# Altium schematic sheet Buck_v1.0_Schematic.SchDoc(Buck_v1.0_Schematic)
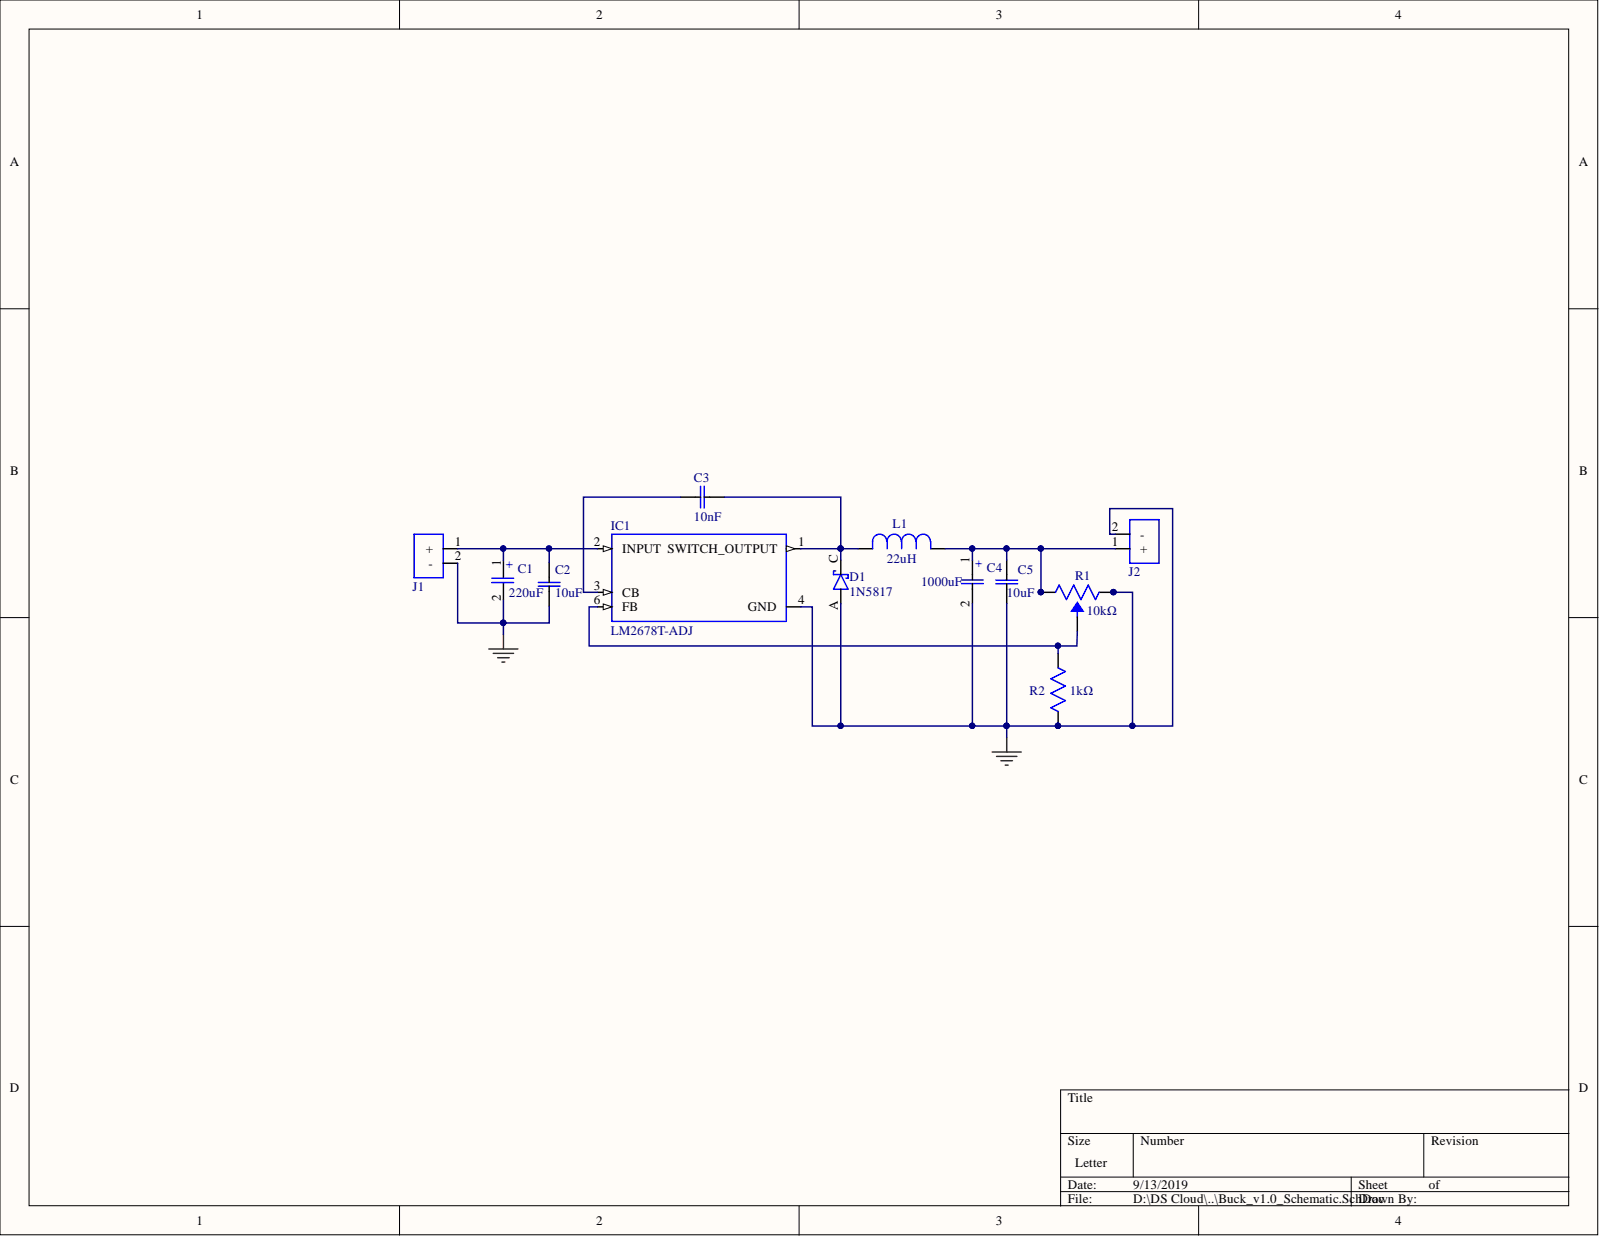
[diagram p0: Buck_v1.0_Schematic.SchDoc]

## Parts
C1  220uF  [Capacitor_220uF_50v]
C2  10uF  [Capacitor_10uF_50v]
C3  10nF  [Capacitor_10nF_50v]
C4  1000uF  [Capacitor_1000uF_50v]
C5  10uF  [Capacitor_10uF_50v]
D1  1N5817  [Diode_Schottky_1A]
IC1  LM2678T-ADJ  [IC_LM2678T-ADJ]
J1  Terminal-2  [Connector_Screw2]  (value not drawn)
J2  Terminal-2  [Connector_Screw2]  (value not drawn)
L1  22uH  [Inductor_22uH]
R1  10kΩ  [POT 10K]
R2  1kΩ  [Resistor_1kohm]

## Nets
GND: C1.2 C2.2 C4.2 C5.1 D1.A IC1.4(GND) J1.2 J2.2 R1.3 R2.1
NetC1_1: C1.1 C2.1 IC1.2(INPUT) J1.1
NetC3_1: C3.1 IC1.3(CB)
NetC3_2: C3.2 D1.C IC1.1(SWITCH_OUTPUT) L1.2
NetC4_1: C4.1 C5.2 J2.1 L1.1 R1.1
NetIC1_6: IC1.6(FB) R1.2 R2.2

## Library data of the parts
C1 = UVZ1H221MPD: CAP ALUM 220UF 20% 50V RADIAL; Manufacturer: Nichicon; Ripple Current: 300mA; Life (Hours): 1000Hour; Leakage Current: 330uA; Lead Diameter: 0.6mm; Dissipation Factor: 0.12%; Dielectric Material: Aluminium; Voltage Rating (DC): 50V; Voltage Rating: 50V; Voltage: 50V; Tolerance: 20%; Termination: Radial; Supplier Part Number 1: 493-1342-ND; Supplier 1: Digi-Key; Stock 1: 28523; RoHS: Yes; Radiation Hardening: No; Pricing 1: 1 = 0.50000, 10 = 0.34700, 100 = 0.20830, 500 = 0.15624, 1000 = 0.13194, 2500 = 0.12499, 5000 = 0.11805 (USD); Packaging: Bulk; Package Quantity: 200; Number of Pins: 2; Mount: Through Hole,PCB; Max Operating Temperature: 105°C; Manufacturer Part Number: UVZ1H221MPD; Library Name: Buck_v1.0_SchematicLib.SchLib; Length: 12.5mm; Lead Pitch: 5mm; Lead Free: Lead Free; Height - Seated (Max): 0.394inch; Height: 12.5mm; Features: General Purpose; Diameter: 10mm; Contact Plating: Tin,Matte; ComponentLink5URL: https://datasheet.ciiva.com/15199/getdatasheetpartid-434790-15199260.pdf?src-supplier=Verical; ComponentLink5Description: https://datasheet.ciiva.com/15199/getdatasheetpartid-434790-15199260.pdf?src-supplier=Verical; ComponentLink4URL: https://datasheet.ciiva.com/14609/70187813-14609113.pdf?src-supplier=Allied+Electronics; ComponentLink4Description: https://datasheet.ciiva.com/14609/70187813-14609113.pdf?src-supplier=Allied+Electronics; ComponentLink3URL: https://datasheet.ciiva.com/11685/e-vz-11685880.pdf?src-supplier=Avnet; ComponentLink3Description: https://datasheet.ciiva.com/11685/e-vz-11685880.pdf?src-supplier=Avnet; ComponentLink2URL: https://datasheet.ciiva.com/5330/e-vz-6403-5330789.pdf?src-supplier=Mouser; ComponentLink2Description: https://datasheet.ciiva.com/5330/e-vz-6403-5330789.pdf?src-supplier=Mouser; ComponentLink1URL: https://datasheet.ciiva.com/97/e-vz-97424.pdf?src-supplier=Digi-Key; ComponentLink1Description: https://datasheet.ciiva.com/97/e-vz-97424.pdf?src-supplier=Digi-Key; Component Kind: Standard; Category: Capacitors; Capacitance: 220uF
C2 C5 = SR655C106KARTR1: Multilayer Ceramic Capacitors MLCC - Leaded 50volts 10uF 10% X7R; Manufacturer: Kyocera AVX; Voltage Rating: 50V; Lead Diameter: 0.508mm; Lead/Base Style: Kinked; Width: 0.15inch; Tolerance: 10%; Termination: Radial; Supplier Part Number 1: 478-7139-1-ND; Supplier 1: Digi-Key; Stock 1: 1670; RoHS: Yes; Radiation Hardening: No; Pricing 1: 1 = 4.13000, 10 = 3.72100, 100 = 2.81130, 500 = 2.39786 (USD); Packaging: Tape and Reel; Package Quantity: 2000; Mount: Through Hole; Min Operating Temperature: -55°C; Max Operating Temperature: 125°C; Manufacturer Part Number: SR655C106KARTR1; Library Name: Buck_v1.0_SchematicLib.SchLib; Length: 0.3inch; Lead Pitch: 5.08mm; Height - Seated (Max): 0.4inch; Dielectric: X7R; ComponentLink3URL: https://datasheet.ciiva.com/13168/skycap-sr-13168744.pdf?src-supplier=Mouser; ComponentLink3Description: https://datasheet.ciiva.com/13168/skycap-sr-13168744.pdf?src-supplier=Mouser; ComponentLink2URL: https://datasheet.ciiva.com/6277/skycap-sr-6277543.pdf?src-supplier=Digi-Key; ComponentLink2Description: https://datasheet.ciiva.com/6277/skycap-sr-6277543.pdf?src-supplier=Digi-Key; ComponentLink1URL: https://datasheet.ciiva.com/6277/skycap-sr-6277541.pdf?src-supplier=Digi-Key; ComponentLink1Description: https://datasheet.ciiva.com/6277/skycap-sr-6277541.pdf?src-supplier=Digi-Key; Component Kind: Standard; Category: Capacitors; Case/Package: Radial; Capacitance: 10uF
C3 = C315C103K5R5TA7303: Multilayer Ceramic Capacitors MLCC - Leaded 50volts 0.01uF 10% X7R; Manufacturer: KEMET; Voltage Rating (DC): 50V; Lead Diameter: 0.51mm; Lead/Base Style: Straight; Dissipation Factor: 2.5%; Width: 0.1inch; Voltage Rating: 50V; Tolerance: 10%; Termination: Radial; Supplier Part Number 1: 399-9858-1-ND; Supplier 1: Digi-Key; Stock 1: 64700; RoHS: Yes; Radiation Hardening: No; Pricing 1: 1 = 0.26000, 10 = 0.17900, 100 = 0.10170, 500 = 0.07176, 1000 = 0.06279 (USD); Packaging: Tape and Reel; Package Quantity: 2500; Mount: Through Hole; Min Operating Temperature: -55°C; Max Operating Temperature: 125°C; Manufacturer Part Number: C315C103K5R5TA7303; Library Name: Buck_v1.0_SchematicLib.SchLib; Length: 0.15inch; Lead Pitch: 2.54mm; Height - Seated (Max): 0.21inch; Height: 2.54mm; Dielectric: X7R; ComponentLink2URL: https://datasheet.ciiva.com/16623/datasheetpn-c315c103k5r5ca7303-16623792.pdf?src-supplier=Avnet+Europe; ComponentLink2Description: https://datasheet.ciiva.com/16623/datasheetpn-c315c103k5r5ca7303-16623792.pdf?src-supplier=Avnet+Europe; ComponentLink1URL: https://datasheet.ciiva.com/6257/datasheetpn-c315c103k5r5ta7303-6257529.pdf?src-supplier=Digi-Key; ComponentLink1Description: https://datasheet.ciiva.com/6257/datasheetpn-c315c103k5r5ta7303-6257529.pdf?src-supplier=Digi-Key; Component Kind: Standard; Category: Capacitors; Case/Package: Radial; Capacitance: 10nF
C4 = UVR1H102MHD: CAP ALUM 1000UF 20% 50V RADIAL; Manufacturer: Kyocera AVX; Polarity: Polar; Life (Hours): 2000Hour; Capacitance: 1mF; Voltage Rating (DC): 50V; Voltage Rating: 50V; Tolerance: 20%; Supplier Part Number 1: 493-1111-ND; Supplier 1: Digi-Key; Stock 1: 13153; RoHS: Yes; Pricing 1: 1 = 0.86000, 10 = 0.65100, 100 = 0.46550, 500 = 0.36036, 1000 = 0.31031, 2500 = 0.29029, 5000 = 0.27528 (USD); Packaging: Bulk; Mount: Through Hole,PCB; Min Operating Temperature: -40°C; Max Operating Temperature: 85°C; Manufacturer Part Number: UVR1H102MHD; Library Name: Buck_v1.0_SchematicLib.SchLib; Lead Pitch: 0.197inch; Height - Seated (Max): 1.063inch; ComponentLink1URL: http://nichicon-us.com/english/products/pdfs/e-mi_tape.pdf?src-supplier=Digi-Key; ComponentLink1Description: http://nichicon-us.com/english/products/pdfs/e-mi_tape.pdf?src-supplier=Digi-Key; Component Kind: Standard; Category: Capacitors; Case/Package: Radial
D1 = 1N5817: DIODE SCHOTTKY 20V 1A DO41; Manufacturer: ON Semiconductor / Fairchild; Polarity: Standard; Peak Reverse Current: 500uA; Peak Non-Repetitive Surge Current: 25A; Max Reverse Leakage Current: 1mA; Forward Voltage: 450mV; Element Configuration: Single; Capacitance: 110pF; Width: 2.7mm; Weight: 0.245g; Voltage Rating (DC): 20V; Supplier Part Number 1: 1N5817DICT-ND; Supplier 1: Digi-Key; RoHS: Yes; REACH SVHC: No SVHC; Radiation Hardening: No; Packaging: Tape and Reel; Package Quantity: 5000; Output Current: 1A; Number of Pins: 2; Mount: Through Hole; Min Operating Temperature: -65°C; Max Surge Current: 25A; Max Reverse Voltage (DC): 20V; Max Repetitive Reverse Voltage (Vrrm): 20V; Max Operating Temperature: 125°C; Max Forward Surge Current (Ifsm): 25A; Manufacturer Part Number: 1N5817; Library Name: Buck_v1.0_SchematicLib.SchLib; Length: 5.2mm; Lead Free: Lead Free; Height: 5.2mm; Forward Current: 1A; Diameter: 2.72mm; Current Rating: 1A; Contact Plating: Tin,Matte; ComponentLink8URL: https://datasheet.ciiva.com/25951/1n5819-25951342.pdf?src-supplier=Avnet+Europe; ComponentLink8Description: https://datasheet.ciiva.com/25951/1n5819-25951342.pdf?src-supplier=Avnet+Europe; ComponentLink7URL: https://datasheet.ciiva.com/8300/1n5819-8300569.pdf?src-supplier=Avnet; ComponentLink7Description: https://datasheet.ciiva.com/8300/1n5819-8300569.pdf?src-supplier=Avnet; ComponentLink6URL: https://datasheet.ciiva.com/1177/1671052-1177031.pdf?src-supplier=Element14; ComponentLink6Description: https://datasheet.ciiva.com/1177/1671052-1177031.pdf?src-supplier=Element14; ComponentLink5URL: https://datasheet.ciiva.com/28468/1671052-28468934.pdf?src-supplier=Element14; ComponentLink5Description: https://datasheet.ciiva.com/28468/1671052-28468934.pdf?src-supplier=Element14; ComponentLink4URL: https://datasheet.ciiva.com/917/1n5817-917189.pdf?src-supplier=Digi-Key; ComponentLink4Description: https://datasheet.ciiva.com/917/1n5817-917189.pdf?src-supplier=Digi-Key; ComponentLink3URL: https://datasheet.ciiva.com/917/1n5817-917188.pdf?src-supplier=Digi-Key; ComponentLink3Description: https://datasheet.ciiva.com/917/1n5817-917188.pdf?src-supplier=Digi-Key; ComponentLink2URL: https://datasheet.ciiva.com/4718/1n5817-d-283828-4718869.pdf?src-supplier=Mouser; ComponentLink2Description: https://datasheet.ciiva.com/4718/1n5817-d-283828-4718869.pdf?src-supplier=Mouser; ComponentLink1URL: https://datasheet.ciiva.com/8303/1n5819-8303634.pdf?src-supplier=Avnet; ComponentLink1Description: https://datasheet.ciiva.com/8303/1n5819-8303634.pdf?src-supplier=Avnet; Component Kind: Standard; Category: Diodes; Average Rectified Current: 1A
IC1 = LM2678T-ADJ/NOPB: Conv DC-DC Single Step Down 8V to 40V 7-Pin(7+Tab) TO-220 Rail; Manufacturer: TI National Semiconductor; Switching Frequency: 260kHz; Quiescent Current: 4.2mA; Output Voltage: 37V; Output Type: Adjustable; Output Current: 5A; Number of Outputs: 1; Min Output Voltage: 1.2V; Efficiency: 84%; Width: 4.57mm; Weight: 0.001lb; Supplier Part Number 1: 17AH9127; Supplier 1: Newark; Stock 1: 861; RoHS: Yes; REACH SVHC: No SVHC; Radiation Hardening: No; Pricing 1: 1 = 5.82000, 10 = 5.24000, 25 = 4.92000, 50 = 4.61000, 100 = 4.29000, 250 = 4.02000, 500 = 3.65000 (USD); Packaging: Rail/Tube; Package Quantity: 45; Operating Supply Voltage: 40V; Number of Pins: 7; Mount: Through Hole; Min Operating Temperature: -40°C; Min Input Voltage: 8V; Max Output Voltage: 37V; Max Output Current: 5A; Max Operating Temperature: 125°C; Max Input Voltage: 40V; Manufacturer Part Number: LM2678T-ADJ/NOPB; Library Name: Buck_v1.0_SchematicLib.SchLib; Length: 10.16mm; Lead Free: Lead Free; Height: 8.69mm; Contact Plating: Tin,Matte; ComponentLink5URL: https://datasheet.ciiva.com/41457/001013913-da-01-en-ic-reg-buc-lm2678t-adj-nopb-to-220-7-tid-41457946.pdf?src-supplier=Conrad; ComponentLink5Description: https://datasheet.ciiva.com/41457/001013913-da-01-en-ic-reg-buc-lm2678t-adj-nopb-to-220-7-tid-41457946.pdf?src-supplier=Conrad; ComponentLink4URL: https://datasheet.ciiva.com/3220/lm2678-3220057.pdf?src-supplier=Avnet; ComponentLink4Description: https://datasheet.ciiva.com/3220/lm2678-3220057.pdf?src-supplier=Avnet; ComponentLink3URL: https://datasheet.ciiva.com/14150/pv641376-e-14150621.pdf?src-supplier=Distrelec; ComponentLink3Description: https://datasheet.ciiva.com/14150/pv641376-e-14150621.pdf?src-supplier=Distrelec; ComponentLink2URL: https://datasheet.ciiva.com/27056/2057801-27056524.pdf?src-supplier=Jameco; ComponentLink2Description: https://datasheet.ciiva.com/27056/2057801-27056524.pdf?src-supplier=Jameco; ComponentLink1URL: https://datasheet.ciiva.com/15112/getdatasheetpartid-87814-15112808.pdf?src-supplier=Verical; ComponentLink1Description: https://datasheet.ciiva.com/15112/getdatasheetpartid-87814-15112808.pdf?src-supplier=Verical; Component Kind: Standard; Category: IC; Case/Package: TO-220
J1 J2 = 282834-2: TERM BLK 2P SIDE ENT 2.54MM PCB; Manufacturer: TE Connectivity; Wire Gauge (Min): 30AWG; Voltage Rating: 150V; Thread Size: M1.6; Tail Length: 3.4mm; Side Stackable: Yes (With); Screw Flange: No (Without); Plating: Brass,Steel; Number of Positions Per Level: 2; Number of Levels: 1; Max Current Rating: 10A; Gender: Male; Connector Type: Receptacle; Approvals: UL; Wire Gauge (Max): 30AWG; Wire/Cable Gauge: 30AWG; Width: 6.5mm; Voltage: 150V; Termination: Solder; Supplier Part Number 1: A98333-ND; Supplier 1: Digi-Key; Stock 1: 35074; Screwless Terminal Block: Yes (With); RoHS: Yes; REACH SVHC: Unknown; Radiation Hardening: No; Pricing 1: 1 = 0.88000, 10 = 0.62000, 25 = 0.57840, 50 = 0.52500, 100 = 0.52280, 250 = 0.44144, 500 = 0.41822, 1000 = 0.37175, 5000 = 0.34852 (USD); Pitch: 2.54mm; Packaging: Bulk; Package Quantity: 300; Orientation: Straight; Number of Rows: 1; Number of Positions: 2; Number of Poles: 2; Number of Contacts: 2; Number of Circuits: 2; Mount: Through Hole; Min Operating Temperature: -40°C; Max Voltage Rating (AC): 150V; Max Supply Voltage (AC): 150V; Max Operating Temperature: 105°C; Material: Nylon; Manufacturer Part Number: 282834-2; Library Name: Buck_v1.0_SchematicLib.SchLib; Length: 5.54mm; Lead Free: Lead Free; Interlock: No (Without); Housing Material: Polyamide; Housing Color: Green; Flammability Rating: UL94 V-0; ELV: Compliant; Depth: 6.5mm; Current Rating: 10A; Current: 10A; Contact Plating: Tin; Contact Material: Brass; ComponentLink8URL: https://datasheet.ciiva.com/16676/eng-cs-1308389-eurostyle-terminal-blocks-0607-16676126.pdf?src-supplier=Avnet+Europe; ComponentLink8Description: https://datasheet.ciiva.com/16676/eng-cs-1308389-eurostyle-terminal-blocks-0607-16676126.pdf?src-supplier=Avnet+Europe; ComponentLink7URL: https://datasheet.ciiva.com/30166/eng-cs-1308389-eurostyle-terminal-blocks-0607-30166974.pdf?src-supplier=Onlinecomponents.com; ComponentLink7Description: https://datasheet.ciiva.com/30166/eng-cs-1308389-eurostyle-terminal-blocks-0607-30166974.pdf?src-supplier=Onlinecomponents.com; ComponentLink6URL: https://datasheet.ciiva.com/823/eng-cd-282834-c1-823453.pdf?src-supplier=Digi-Key; ComponentLink6Description: https://datasheet.ciiva.com/823/eng-cd-282834-c1-823453.pdf?src-supplier=Digi-Key; ComponentLink5URL: https://datasheet.ciiva.com/3141/eng-cs-1308389-eurostyle-terminal-blocks-0607-3141115.pdf?src-supplier=Avnet; ComponentLink5Description: https://datasheet.ciiva.com/3141/eng-cs-1308389-eurostyle-terminal-blocks-0607-3141115.pdf?src-supplier=Avnet; ComponentLink4URL: https://datasheet.ciiva.com/15169/getdatasheetpartid-155864-15169558.pdf?src-supplier=Verical; ComponentLink4Description: https://datasheet.ciiva.com/15169/getdatasheetpartid-155864-15169558.pdf?src-supplier=Verical; ComponentLink3URL: https://datasheet.ciiva.com/14609/70086732-14609670.pdf?src-supplier=Allied+Electronics; ComponentLink3Description: https://datasheet.ciiva.com/14609/70086732-14609670.pdf?src-supplier=Allied+Electronics; ComponentLink2URL: https://datasheet.ciiva.com/16386/282834-2-16386363.pdf?src-supplier=Future+Electronics; ComponentLink2Description: https://datasheet.ciiva.com/16386/282834-2-16386363.pdf?src-supplier=Future+Electronics; ComponentLink1URL: https://datasheet.ciiva.com/11758/0900766b80e7dc96-11758675.pdf?src-supplier=RSComponents; ComponentLink1Description: https://datasheet.ciiva.com/11758/0900766b80e7dc96-11758675.pdf?src-supplier=RSComponents; Component Kind: Standard; Color: Green; Closed End: No (Without); Category: Connectors
L1 = 7443551221: WURTH ELEKTRONIK - 7443551221 - HCI INDUCTANCE 22UH 24.70MOHM 6.5A; Manufacturer: Wurth Electronics; Tolerance: 20%; Test Frequency: 100kHz; Shielding: Shielded; Series Resistance: 24.7R; Self Resonant Frequency: 14MHz; RMS Current (Irms): 6A; DC Resistance (DCR): 24.7mR; Width: 12.8mm; Termination: SMD/SMT; Supplier Part Number 1: 732-2183-1-ND; Supplier 1: Digi-Key; Stock 1: 1201; Saturation Current: 6.5A; RoHS: Yes; REACH SVHC: Unknown; Pricing 1: 1 = 3.27000, 10 = 3.20300, 50 = 2.95700, 100 = 2.83400, 200 = 2.64900 (USD); Packaging: Tape and Reel; Package Quantity: 1; Mount: Surface Mount; Min Operating Temperature: -40°C; Max Operating Temperature: 155°C; Max DC Current: 6A; Manufacturer Part Number: 7443551221; Library Name: Buck_v1.0_SchematicLib.SchLib; Length: 13.2mm; Inductance Tolerance: 20%; Inductance: 22uH; Height - Seated (Max): 0.256inch; Height: 6.2mm; Depth: 12.8mm; Current Rating: 6A; Composition: Wirewound; ComponentLink4URL: https://datasheet.ciiva.com/11800/0900766b80f887f1-11800527.pdf?src-supplier=RSComponents; ComponentLink4Description: https://datasheet.ciiva.com/11800/0900766b80f887f1-11800527.pdf?src-supplier=RSComponents; ComponentLink3URL: https://datasheet.ciiva.com/13277/7443551221-89580-13277041.pdf?src-supplier=Mouser; ComponentLink3Description: https://datasheet.ciiva.com/13277/7443551221-89580-13277041.pdf?src-supplier=Mouser; ComponentLink2URL: https://datasheet.ciiva.com/7691/1735911-7691584.pdf?src-supplier=Element14; ComponentLink2Description: https://datasheet.ciiva.com/7691/1735911-7691584.pdf?src-supplier=Element14; ComponentLink1URL: https://datasheet.ciiva.com/6699/7443551221-6699920.pdf?src-supplier=Digi-Key; ComponentLink1Description: https://datasheet.ciiva.com/6699/7443551221-6699920.pdf?src-supplier=Digi-Key; Component Kind: Standard; Category: Inductors; Case/Package: 1365
R1 = Pot 10K Blue: Potentiometer 10KOHM TOP ADJUST; Manufacturer Part Number 1: HDR-3296-1; Manufacturer 1: Hilitchi; Supplier Unit Price 1: 0.199; Supplier Subtotal 1: 11.97; Supplier Stock 1: 115485; Supplier Order Qty 1: 60; Supplier Currency 1: USD; Supplier 1: Amazon; Library Name: Buck_v1.0_SchematicLib.SchLib; Component Kind: Standard; Category: Potentiometer; Case/Package: Top Adjust
R2 = RNF14FTD1K00: RES 1K OHM 1/4W 1% AXIAL; Manufacturer: Stackpole Electronics; Temperature Coefficient: 100ppm/°C; Resistance: 1kR; Power Rating: 250mW; Tolerance: 1%; Termination: Axial; Supplier Part Number 1: RNF14FTD1K00CT-ND; Supplier 1: Digi-Key; Stock 1: 284752; RoHS: Yes; Radiation Hardening: No; Pricing 1: 1 = 0.10000, 10 = 0.07200, 25 = 0.04960, 50 = 0.03860, 100 = 0.02750, 250 = 0.02144, 500 = 0.01706, 1000 = 0.01210 (USD); Packaging: Tape and Reel; Package Quantity: 1; Number of Terminations: 2; Mount: Through Hole,Axial; Min Operating Temperature: -55°C; Military Standard: Not; Max Power Dissipation: 250mW; Max Operating Temperature: 155°C; Manufacturer Part Number: RNF14FTD1K00; Library Name: Buck_v1.0_SchematicLib.SchLib; Length: 6.35mm; Lead Free: Lead Free; Features: Flame Retardant Coating; Diameter: 2.35mm; Contact Plating: Copper,Tin; Composition: Metal Film; ComponentLink5URL: http://www.seielect.com/Catalog/SEI-RNF_RNMF.pdf?src-supplier=Future+Electronics; ComponentLink5Description: http://www.seielect.com/Catalog/SEI-RNF_RNMF.pdf?src-supplier=Future+Electronics; ComponentLink4URL: https://www.verical.com/server-webapp/html/getDatasheet?partID=360720&src-supplier=Verical; ComponentLink4Description: https://www.verical.com/server-webapp/html/getDatasheet?partID=360720&src-supplier=Verical; ComponentLink3URL: https://www.seielect.com/Catalog/SEI-RNF_RNMF.pdf?src-supplier=Digi-Key; ComponentLink3Description: https://www.seielect.com/Catalog/SEI-RNF_RNMF.pdf?src-supplier=Digi-Key; ComponentLink2URL: https://www.seielect.com/Catalog/SEI-RNF_RNMF.pdf?src-supplier=Avnet; ComponentLink2Description: https://www.seielect.com/Catalog/SEI-RNF_RNMF.pdf?src-supplier=Avnet; ComponentLink1URL: http://components.arrow.com/part/dataview/50573548S9848899N1174?src-supplier=Arrow; ComponentLink1Description: http://components.arrow.com/part/dataview/50573548S9848899N1174?src-supplier=Arrow; Component Kind: Standard; Category: Resistors; Case/Package: Conformal
